# Altium schematic sheet PowerSupply.SchDoc(PowerSupply)
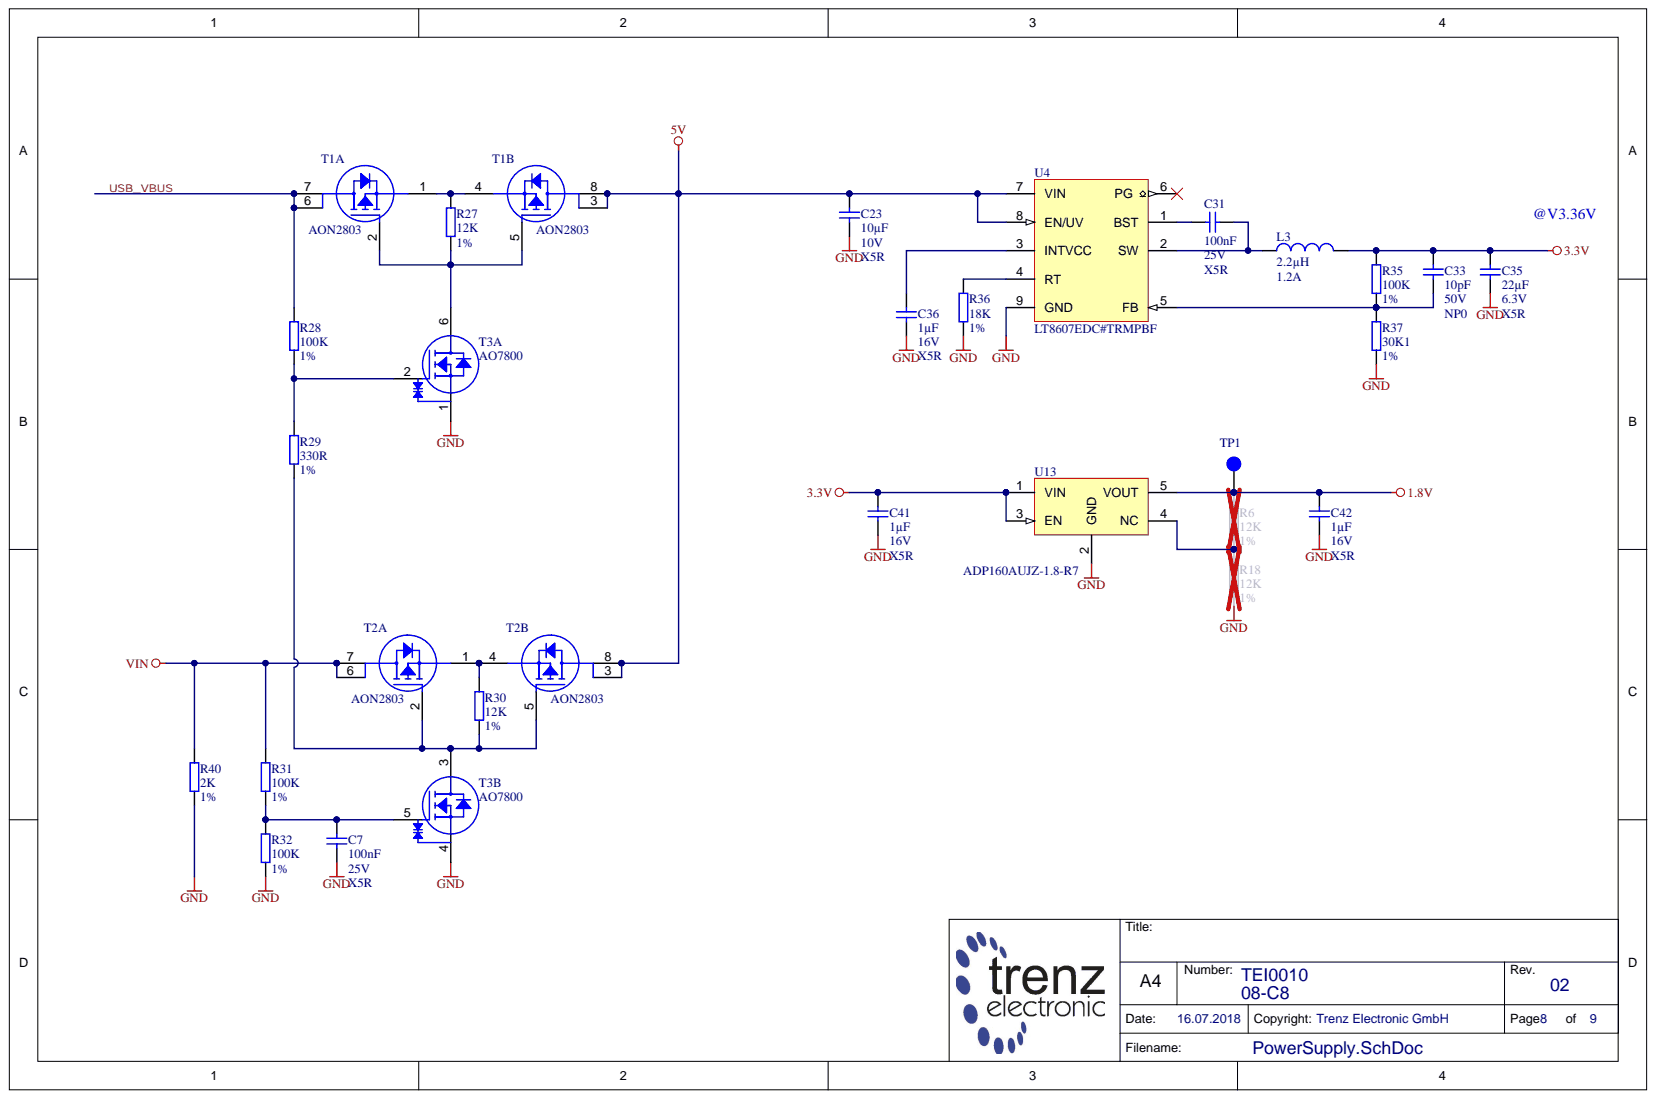
[diagram p0: PowerSupply.SchDoc]

## Parts
C7  100nF  Technology: X5R  Voltage: 25V  [CAPC1005X55N]
C23  10µF  Technology: X5R  Voltage: 10V  [CAPC2012X135N]
C31  100nF  Voltage: 25V  [CAPC1005X55N]
C33  10pF  Technology: NP0  Voltage: 50V  [CAPC1005X55N]
C35  22µF  Technology: X5R  Voltage: 6.3V  [CAPC1608X90N]
C36  1µF  Technology: X5R  Voltage: 16V  [CAPC1005X55N]
C41  1µF  Technology: X5R  Voltage: 16V  [CAPC1005X55N]
C42  1µF  Technology: X5R  Voltage: 16V  [CAPC1005X55N]
L3  2.2µH  Voltage: 1.2A  [INDC2016X100L50N]
R6  12K  Tolerance: 1%  [RESC1005X40N]
R18  12K  Tolerance: 1%  [RESC1005X40N]
R27  12K  Tolerance: 1%  [RESC1005X40N]
R28  100K  Tolerance: 1%  [RESC1005X40N]
R29  330R  Tolerance: 1%  [RESC1005X40N]
R30  12K  Tolerance: 1%  [RESC1005X40N]
R31  100K  Tolerance: 1%  [RESC1005X40N]
R32  100K  Tolerance: 1%  [RESC1005X40N]
R35  100K  Tolerance: 1%  [RESC1005X40N]
R36  18K  Tolerance: 1%  [RESC1005X40N]
R37  30K1  Tolerance: 1%  [RESC1005X40N]
R40  2K  Tolerance: 1%  [RESC1005X40N]
T1  AON2803  [SON65P200X200X80-8T10X06]  (parts A,B)
T2  AON2803  [SON65P200X200X80-8T10X06]  (parts A,B)
T3  AO7800  [SOT65P210X110-6N]  (parts A,B)
TP1  Testpoint 0.8mm  [TP_0.8mm]  (value not drawn)
U4  LT8607EDC#TRMPBF  [SON45P200X200X85-9T23X180N]
U13  ADP160AUJZ-1.8-R7  [SOT95P280X100-5N]

## Nets
1.8V: C42.1 R6.2 TP1.1 U13.5(VOUT)
3.3V: C33.1 C35.1 C41.1 L3.2 R35.1 U13.1(VIN) U13.3(EN)
5V: C23.1 T1.3 T1.8 T2.3 T2.8 U4.7(VIN) U4.8(EN/UV)
GND: C7.1 C23.2 C35.2 C36.2 C41.2 C42.2 R18.1 R32.2 R36.2 R37.2 R40.1 T3.1 T3.4 U4.9(GND) U13.2(GND)
VIN: R31.1 R40.2 T2.6 T2.7
USB_VBUS: R28.1 T1.6 T1.7
NetC7_2: C7.2 R31.2 R32.1 T3.5
NetC31_1: C31.1 U4.1(BST)
NetC31_2: C31.2 L3.1 U4.2(SW)
NetC33_2: C33.2 R35.2 R37.1 U4.5(FB)
NetC36_1: C36.1 U4.3(INTVCC)
NetR6_1: R6.1 R18.2 U13.4(NC)
NetR27_1: R27.1 T1.2 T1.5 T3.6
NetR27_2: R27.2 T1.1 T1.4
NetR28_2: R28.2 R29.2 T3.2
NetR29_1: R29.1 R30.1 T2.2 T2.5 T3.3
NetR30_2: R30.2 T2.1 T2.4
NetR36_1: R36.1 U4.4(RT)

Not connected: U4 6(PG)

## Library data of the parts
C7 C31 = 22472: KON 100nF 25V X5R ±10% SMD BF 1005 (0402); Database Table Name: C_1005_0402; Mixed: SMT; Preferred: Yes; Temperature-Range: -55/+85; Value: 100n; AN: 22472; Case: 1005 (0402); Component Kind: Standard; Library Name: TE.DbLib
  C31: Technology: X5R
C23 = 28014: KON 10µF 10V X5R 10% SMD BF 2012 (0805); Database Table Name: C_2012_0805; Mixed: SMT; Temperature-Range: -55/+85; Value: 10u; AN: 28014; Case: 2012 (0805); Component Kind: Standard; Library Name: TE.DbLib; Tolerance: 10%
C33 = 22597: KON 10pF 50V NP0 5% SMD BF 1005 (0402); Database Table Name: C_1005_0402; Mixed: SMT; Preferred: Yes; Value: 10p; AN: 22597; Case: 1005 (0402); Component Kind: Standard; Library Name: TE.DbLib; Tolerance: 5%
C35 = 25400: KON 22µF 6,3V X5R SMD BF 1608 (0603); Database Table Name: C_1608_0603; Mixed: SMT; Temperature-Range: -55/+85; Value: 22u; AN: 25400; Case: 1608 (0603); Component Kind: Standard; Library Name: TE.DbLib
C36 C41 C42 = 26579: KON 1µF 16V X5R 10% SMD BF 1005 (0402); Database Table Name: C_1005_0402; Mixed: SMT; Temperature-Range: -55/+85; Value: 1u; AN: 26579; Case: 1005 (0402); Component Kind: Standard; Library Name: TE.DbLib; Tolerance: 10%
L3 = 28951: IND SMD 2.2µH 2.2A, 145 mOhm 0806; Database Table Name: L_2012_0805; H-ART: SRN2010TA-2R2M; Mixed: SMT; Tolerance: ±20%; Value: 2.2u; AN: 28951; Case: 2016 (0806); Component Kind: Standard; Library Name: TE.DbLib; Technology: 145mOhm; HelpURL: b:\AD\adlib\datasheet\SRN2010TA\
R6 R18 R27 R30 = 22598: WID SMD 12K 1% 0,063W  BF 1005 (0402); Database Table Name: R_1005_0402; Mixed: SMT; Preferred: Yes; AN: 22598; Case: 1005 (0402); Component Kind: Standard; Library Name: TE.DbLib; Technology: TK100; Value: 12K
R28 R31 R32 R35 = 22558: WID SMD 100K 1% 0,063W; Database Table Name: R_1005_0402; H-ART: RC0402FR-13100KL; Mixed: SMT; Preferred: Yes; AN: 22558; Case: 1005 (0402); Component Kind: Standard; Library Name: TE.DbLib; Technology: TK100; Value: 100K
R29 = 22762: WID SMD 330R 1% 0,063W; Database Table Name: R_1005_0402; H-ART: RC0402FR-13330RL; Mixed: SMT; Preferred: Yes; Value: 330; AN: 22762; Case: 1005 (0402); Component Kind: Standard; Library Name: TE.DbLib; Technology: TK100
R36 = 24875: WID SMD 18K 1% 0,063W; Database Table Name: R_1005_0402; Mixed: SMT; Preferred: Yes; AN: 24875; Case: 1005 (0402); Component Kind: Standard; Library Name: TE.DbLib; Technology: TK100; Value: 18K
R37 = 23849: WID SMD 30K1 1% 0,063W; Database Table Name: R_1005_0402; Mixed: SMT; Value: 30.1k; AN: 23849; Case: 1005 (0402); Component Kind: Standard; Library Name: TE.DbLib; Technology: TK100
R40 = 25073: WID SMD 2K 1% 0,063W; Database Table Name: R_1005_0402; H-ART: RC0402FR-072KL; Mixed: SMT; AN: 25073; Case: 1005 (0402); Component Kind: Standard; Library Name: TE.DbLib; Technology: TK100; Value: 2K
T1 T2 = 28041: Database Table Name: TRANSISTOR; Mixed: SMT; Temperature-Range: -55/+150 (Tj abs); Value: -3.8A; Voltage: -20V; AN: 28041; Case: DFN6; Component Kind: Standard; Description: AON2803; H-ART: AON2803; Library Name: TE.DbLib; HelpURL: b:\AD\adlib\datasheet\AON2803\
T3 = 28043: Database Table Name: TRANSISTOR; Mixed: SMT; Temperature-Range: -55/+150 (Tj abs); Value: 0.9A; Voltage: 20V; AN: 28043; Case: SOT23-6; Component Kind: Standard; Description: AO7800; H-ART: AO7800; Library Name: TE.DbLib; HelpURL: b:\AD\adlib\datasheet\AO7800\
TP1 = 26289: Database Table Name: PCB; Mixed: MECH; AN: 26289; Component Kind: Standard; Description: Testpoint 0.8mm; Library Name: TE.DbLib
U4 = 28913: Database Table Name: DCDC; Mixed: SMT; AN: 28913; Case: DFN8; Component Kind: Standard; Description: LT8607EDC#TRMPBF; H-ART: LT8607EDC#TRMPBF; Library Name: TE.DbLib; HelpURL: b:\AD\adlib\datasheet\LT8607\
U13 = 28939: Database Table Name: LREG; Mixed: SMT; Voltage: 1.8V; AN: 28939; Case: SOT23-5; Component Kind: Standard; Description: ADP160AUJZ-1.8-R7; H-ART: ADP160AUJZ-1.8-R7; Library Name: TE.DbLib; HelpURL: b:\AD\adlib\datasheet\ADP16x\
